# Altium schematic sheet Current_Voltage_Sensor_ACS.SchDoc
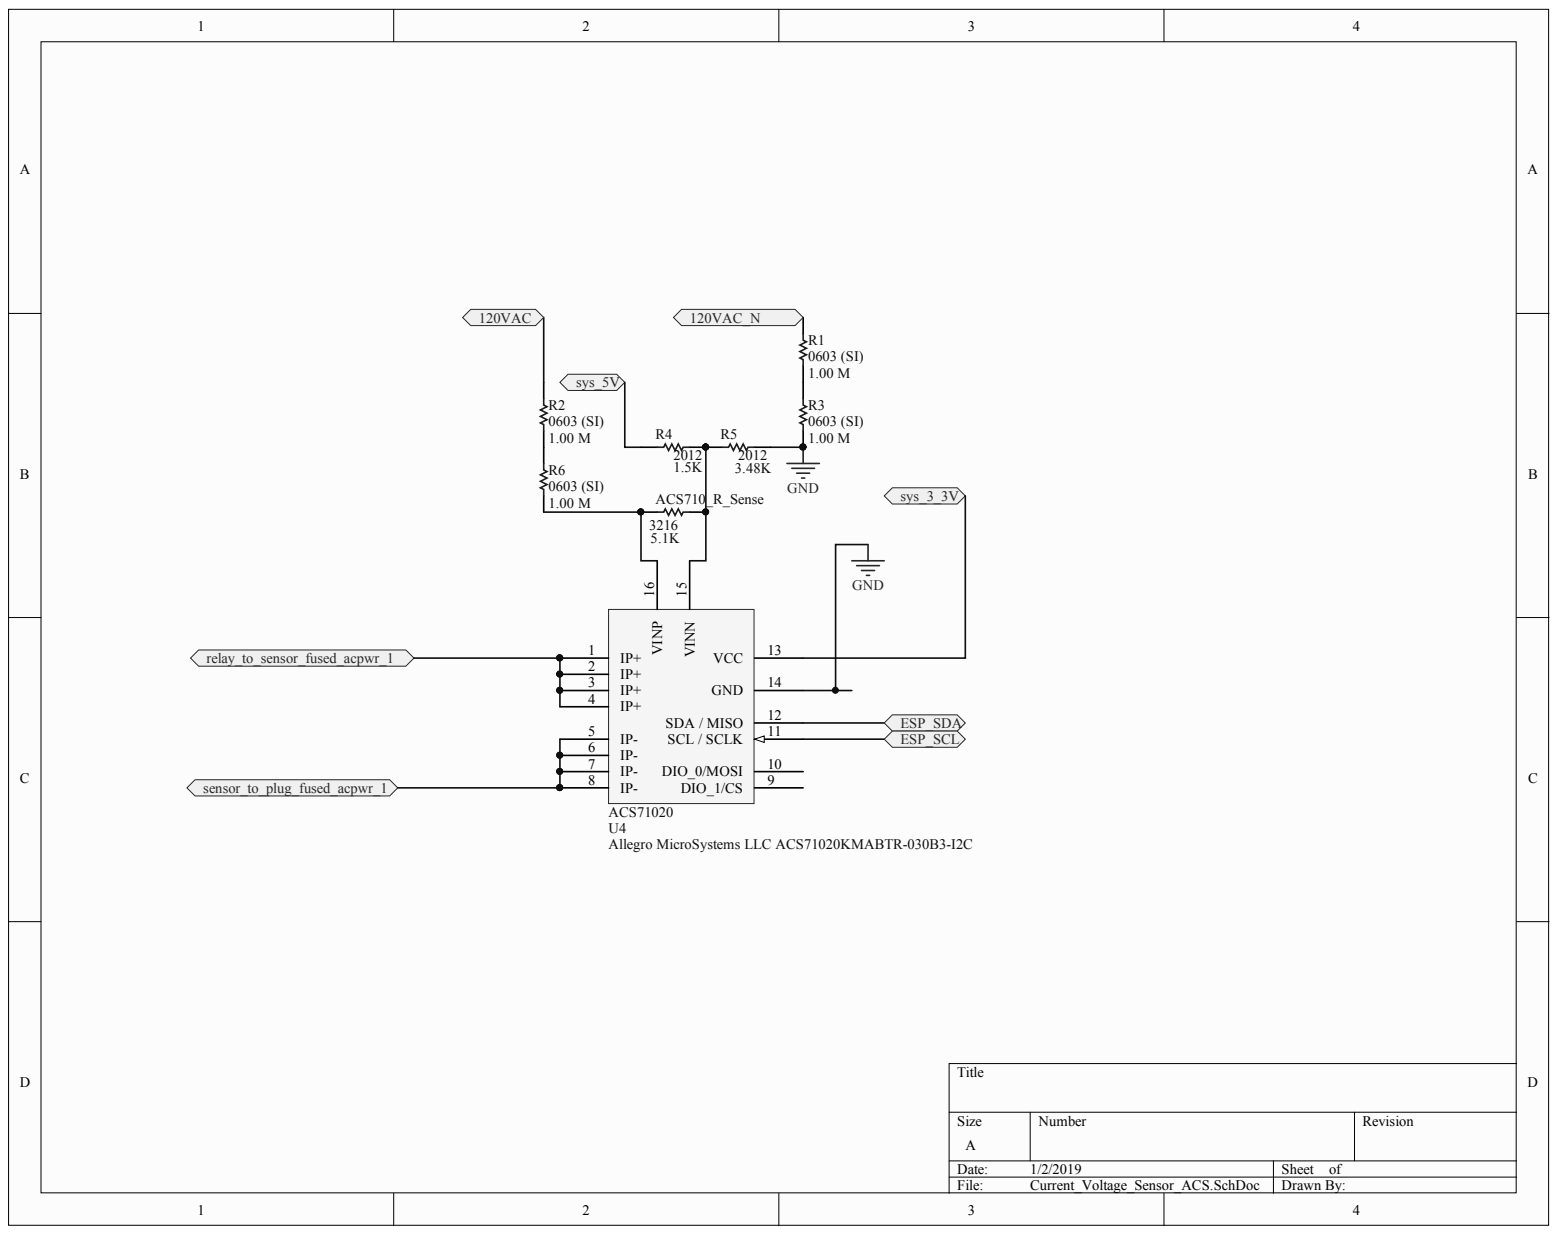
[diagram p0: Current_Voltage_Sensor_ACS.SchDoc]

## Parts
ACS710_R_Sense  Package/Case: 3216  Resistance: 5.1K  [PCB-w608zr93f2jrqwdmzt1l-1]  (hidden comment: Res1)
R1  Yageo RC0201FR-071ML  [PCB-izhuxvfgpg1kpuec29hj-1]  (value not drawn)
R2  Yageo RC0201FR-071ML  [PCB-izhuxvfgpg1kpuec29hj-1]  (value not drawn)
R3  Yageo RC0201FR-071ML  [PCB-izhuxvfgpg1kpuec29hj-1]  (value not drawn)
R4  Package/Case: 2012  Resistance: 1.5K  [RESC2013X55X40NL15T20]  (hidden comment: Vishay Dale TNPU0805100KBZEN00)
R5  Package/Case: 2012  Resistance: 3.48K  [RESC2013X55X40NL15T20]  (hidden comment: Vishay Dale TNPU0805100KBZEN00)
R6  Yageo RC0201FR-071ML  [PCB-izhuxvfgpg1kpuec29hj-1]  (value not drawn)
U4  Allegro MicroSystems LLC ACS71020KMABTR-030B3-I2C  Manufacturer Part Number: ACS71020KMABTR-030B3-I2C  [ACS71020]

## Ports
120VAC, 120VAC_N, ESP_SCL, ESP_SDA, RELAY_TO_SENSOR_FUSED_ACPWR_1, SENSOR_TO_PLUG_FUSED_ACPWR_1, SYS_3_3V, SYS_5V

## Nets
GND: R3.1 R5.2 U4.14(GND)
RELAY_TO_SENSOR_FUSED_ACPWR_1: U4.1(IP+) U4.2(IP+) U4.3(IP+) U4.4(IP+) ; port RELAY_TO_SENSOR_FUSED_ACPWR_1
SENSOR_TO_PLUG_FUSED_ACPWR_1: U4.5(IP-) U4.6(IP-) U4.7(IP-) U4.8(IP-) ; port SENSOR_TO_PLUG_FUSED_ACPWR_1
SYS_3_3V: U4.13(VCC) ; port SYS_3_3V
SYS_5V: R4.1 ; port SYS_5V
120VAC: R2.2 ; port 120VAC
120VAC_N: R1.2 ; port 120VAC_N
ESP_SCL: U4.11 ; port ESP_SCL
ESP_SDA: U4.12(SDA_/_MISO) ; port ESP_SDA
NetACS710_R_Sense_1: ACS710_R_Sense.1 R6.1 U4.16(VINP)
NetACS710_R_Sense_2: ACS710_R_Sense.2 R4.2 R5.1 U4.15(VINN)
NetR1_1: R1.1 R3.2
NetR2_1: R2.1 R6.2

Not connected: U4 9(DIO_1/CS) 10(DIO_0/MOSI)

## Library data of the parts
ACS710_R_Sense = CMP-027ac92f87baddbd-2: Resistor; Thick Film; Res 0.005 Ohms; Pwr-Rtg 1 W; Tol 1%; SMT; 2512; Curr-Sense; CT; Component Kind: Standard; Library Name: CommunityVault; Number of Pins: 2
R1-R3 R6 = CMP-002acbb13d71dfed-1: Res Thick Film 0201 1M Ohm 1% 1/20W ±200ppm/°C Molded SMD SMD Paper T/R; Lead-Free Status: Lead Free; Power Rating: 50.0 mW; Resistance: 1.00 M; Temperature Coefficient: -200 ppm/°C to 200 ppm/°C; Voltage Rating (DC): 25.0 V; Case/Package: 0603 (SI); Component Kind: Standard; Library Name: CommunityVault; Manufacturer Part Number: RC0201FR-071ML; Mounting Style: Surface Mount; OctopartID: 002acbb13d71dfed; Octopart URL: https://octopart.com/rc0201fr-071ml-yageo-43063357; Packaging: Tape & Reel; REACH SVHC Compliance: No SVHC; Resistance Tolerance: ±1%; RoHS: Compliant
R4 R5 = CMP-1878956-2: Thin Film Resistors - SMD 1/8watt 100Kohms 0.1% 5ppm; Power Rating: 0.125 W; Voltage Rating (DC): 150.0 V; Component Kind: Standard; Library Name: CommunityVault; Manufacturer Part Number: TNPU0805100KBZEN00; OctopartID: 002acb85854fdfed; Octopart Url: https://octopart.com/tnpu0805100kbzen00-vishay+dale-44408835; Packaging: Cut Tape (CT); Resistance Tolerance: ±0.1%; RoHS: Exempt
U4 = CMP-c7eb0b7c5e75182c-1: Single Phase, Isolated, Power Mo, +/-30A,3V3, 16 SOIC W; Component Kind: Standard; Library Name: CommunityVault; Manufacturer: Allegro Microsystems; OctopartID: c7eb0b7c5e75182c; Octopart URL: https://octopart.com/acs71020kmabtr-030b3-i2c-allegro+microsystems+llc-94223608; Package: 16 SOIC-W; RoHS: Compliant
